# Altium schematic sheet DigitalMaze.SchDoc(DigitalMaze)
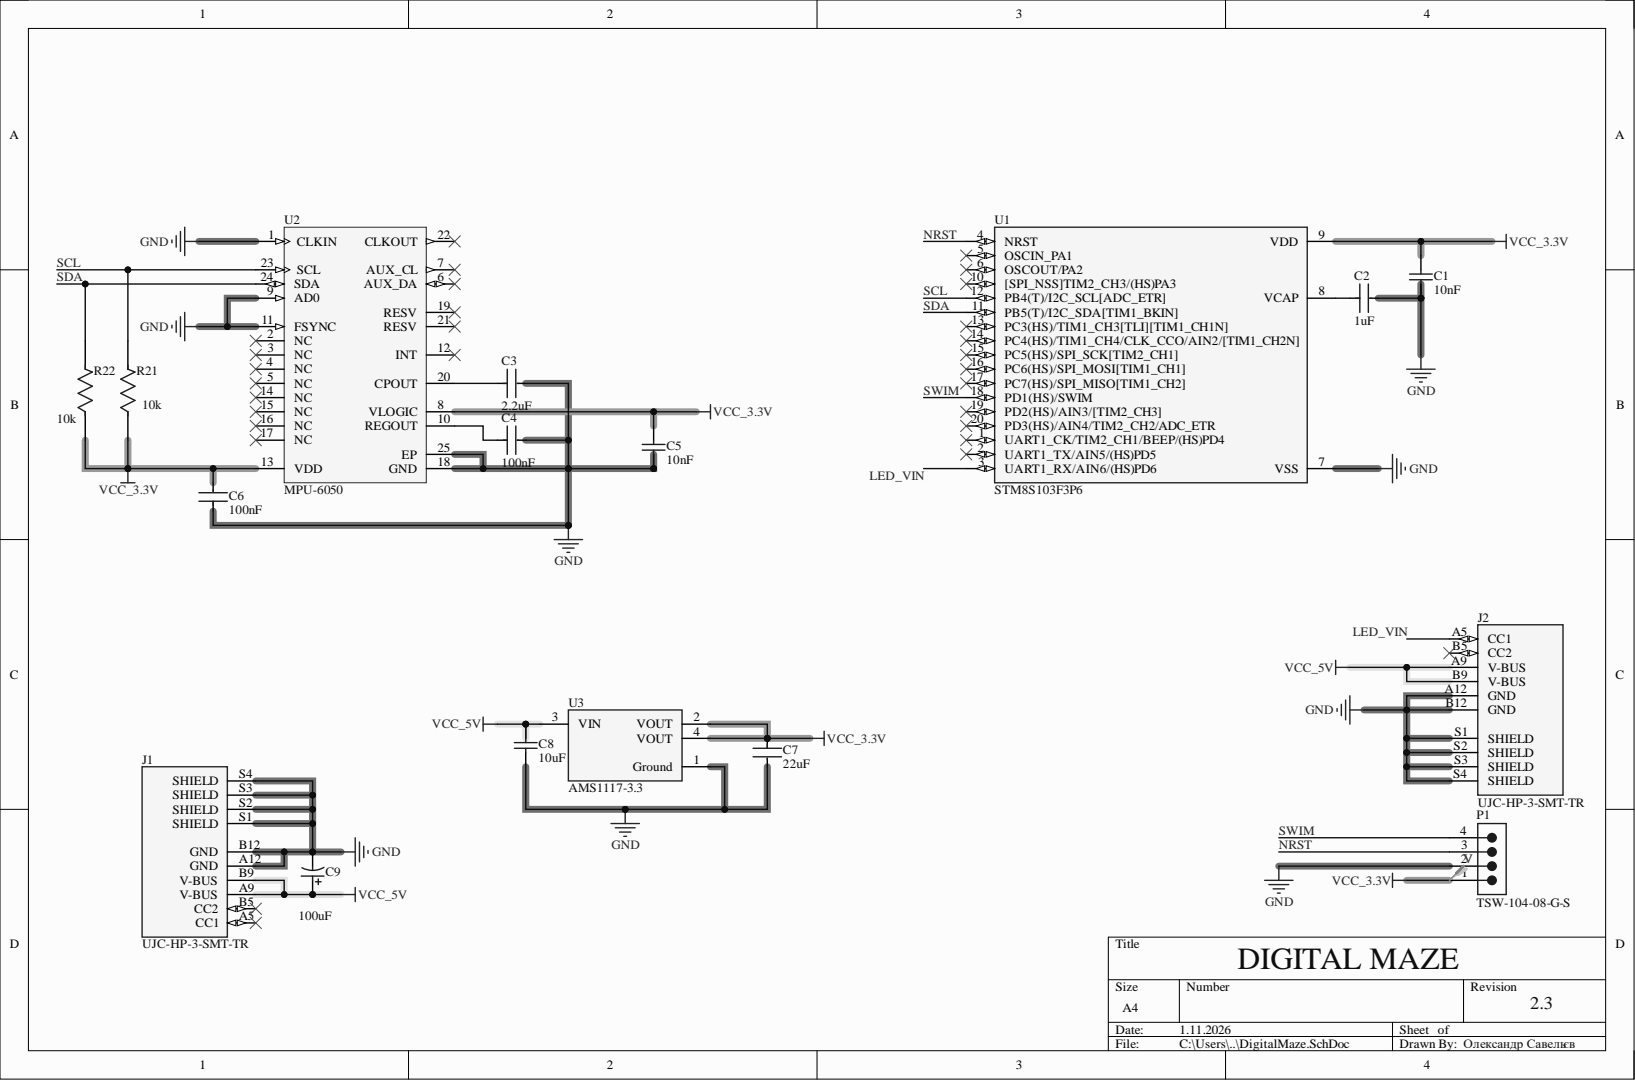
[diagram p0: DigitalMaze.SchDoc]

## Parts
C1  10nF  [CAPC1206(3216)150_N]
C2  1uF  [FP-CGA5L-0_3-0_1-MFG]
C3  2.2uF  [FP-1206-L_3_2_0_15-W_1_6-MFG]
C4  100nF  [FP-C1206C-EB-MFG]
C5  10nF  [CAPC1206(3216)150_N]
C6  100nF  [FP-C1206C-EB-MFG]
C7  22uF  [FP-GRM21B-0_2-e0_2_0_7-MFG]
C8  10uF  [CAPC1206(3216)190_N]
C9  100uF  [PNSC-FK-V-D8_V]
J1  UJC-HP-3-SMT-TR  [CUI_UJC-HP-3-SMT-TR]
J2  UJC-HP-3-SMT-TR  [CUI_UJC-HP-3-SMT-TR]
P1  TSW-104-08-G-S  [SMTC-TSW-104-08-X-S]
R21  10k  [FP-RT1206-MFG]
R22  10k  [FP-RT1206-MFG]
U1  STM8S103F3P6  [SOP65P640X120-20N]
U2  MPU-6050  [IS-QFN-24_V]
U3  AMS1117-3.3  [FP-AMS1117-IPC_C]

## Nets
GND: C1.1 C2.2 C3.2 C4.2 C5.1 C6.1 C7.2 C8.1 C9.2 J1.A12(GND) J1.B12(GND) J1.S1(SHIELD) J1.S2(SHIELD) J1.S3(SHIELD) J1.S4(SHIELD) J2.A12(GND) J2.B12(GND) J2.S1(SHIELD) J2.S2(SHIELD) J2.S3(SHIELD) J2.S4(SHIELD) P1.2 U1.7(VSS) U2.1(CLKIN) U2.9(AD0) U2.11(FSYNC) U2.18(GND) U2.25(EP) U3.1(Ground)
VCC_3.3V: C1.2 C5.2 C6.2 C7.1 P1.1 R21.1 R22.1 U1.9(VDD) U2.8(VLOGIC) U2.13(VDD) U3.2(VOUT) U3.4(VOUT)
VCC_5V: C8.2 C9.1 J1.A9(V-BUS) J1.B9(V-BUS) J2.A9(V-BUS) J2.B9(V-BUS) U3.3(VIN)
LED_VIN: J2.A5(CC1) U1.3(UART1_RX/AIN6/(HS)PD6)
NRST: P1.3 U1.4(NRST)
SCL: R21.2 U1.12(PB4(T)/I2C_SCL[ADC_ETR]) U2.23(SCL)
SDA: R22.2 U1.11(PB5(T)/I2C_SDA[TIM1_BKIN]) U2.24(SDA)
SWIM: P1.4 U1.18(PD1(HS)/SWIM)
NetC2_1: C2.1 U1.8(VCAP)
NetC3_1: C3.1 U2.20(CPOUT)
NetC4_1: C4.1 U2.10(REGOUT)

Not connected: J1 A5(CC1) B5(CC2); J2 B5(CC2); U1 1(UART1_CK/TIM2_CH1/BEEP/(HS)PD4) 2(UART1_TX/AIN5/(HS)PD5) 5(OSCIN_PA1) 6(OSCOUT/PA2) 10([SPI_NSS]TIM2_CH3/(HS)PA3) 13(PC3(HS)/TIM1_CH3[TLI][TIM1_CH1N]) 14(PC4(HS)/TIM1_CH4/CLK_CCO/AIN2/[TIM1_CH2N]) 15(PC5(HS)/SPI_SCK[TIM2_CH1]) 16(PC6(HS)/SPI_MOSI[TIM1_CH1]) 17(PC7(HS)/SPI_MISO[TIM1_CH2]) 19(PD2(HS)/AIN3/[TIM2_CH3]) 20(PD3(HS)/AIN4/TIM2_CH2/ADC_ETR); U2 2(NC) 3(NC) 4(NC) 5(NC) 6(AUX_DA) 7(AUX_CL) 12(INT) 14(NC) 15(NC) 16(NC) 17(NC) 19(RESV) 21(RESV) 22(CLKOUT)

## Library data of the parts
C1 C5 = CMP-1037-03492-1: CAP 10nF 25V ±10% 1206 (3216 Metric) Thickness 1.45mm SMD; Case-EIA: 1206; Case-Metric: 3216; Tolerance: Â±10%; Component Kind: Standard; Library Name: Altium Content Vault; Max Thickness: 1.45 mm; Rated Voltage: 25 V; Technology: SMT; Value: 10nF
C2 = CMP-08246-001699-1: Cap Ceramic 1uF 25V X7R 10% Pad SMD 1206 +125°C Automotive T/R; Capacitance: 1uF; Case Code (Imperial): 1206; Case Code (Metric): 3216; Case/Package: 1206; Component Kind: Standard; Composition: Ceramic; Depth: 1.6mm; Dielectric: X7R; Height: 1.6mm; Length: 3.2mm; Library Name: Altium Content Vault; Max Operating Temperature: 125°C; Min Operating Temperature: -55°C; Mount: Surface Mount; Package Quantity: 2000; Packaging: Tape and Reel; Ratings: AEC-Q200; REACH SVHC: No SVHC; Termination: SMD/SMT; Thickness: 0.075inch; Tolerance: 10%; Voltage Rating: 25V; Voltage Rating (DC): 25V; Weight: 0.000571oz; Width: 1.6mm
C3 = CMP-14477-002875-2: None; Dielectric: X7R; Tolerance: 20%; Voltage: 50V; Capacitance: 2.2uF; Component Kind: Standard; Depth: 1.6mm; Halogen Free: Halogen Free; Height: 1.6mm; Lead Free: Lead Free; Length: 3.2mm; Library Name: Altium Content Vault; Max Operating Temperature: 125°C; Min Operating Temperature: -55°C; Mount: Surface Mount; RoHS Compliant: Yes; Voltage Rating (DC): 50V
C4 C6 = CMP-03020-018297-1: C1206 0.10 μF U2J 20.00% 50 V; Manufacturer: KEMET; Manufacturer Part Number: C1206C104M5JACAUTO; Automotive Grade: Grade 0; Capacitance: 0.10 μF; Material: Ceramic; Temperature Coefficient: 15%; Tolerance: 0.2; Value: 0.10 μF; Voltage Rating: 50 V; Automotive: Yes; Category: Cap; Component Kind: Standard; ComponentLink2Description: Datasheet; ComponentLink2URL: https://content.kemet.com/datasheets/KEM_C1007_X8R_ULTRA_150C_SMD.pdf; Device Class L1: Passive Components; Device Class L2: Capacitors; Device Class L3: Ceramic Capacitors; Height: 0.88mm; Ipc Land Pattern Name: CAPC320160X78; Lead Free: Yes; Library Name: Altium Content Vault; Octopart: https://octopart.com/c1206c104m5jacauto-kemet-84732526; Package: C1206C-EB; RoHS: TRUE; Temperature: -55°C to +150°C; Temperature Characteristic: U2J; Temperature Range High: +150°C; Temperature Range Low: -55°C
C7 = CMP-06035-036790-1: Chip Multilayer Ceramic Capacitors for General Purpose, 0805, 22uF, X5R, 15%, 20%, 25V; Capacitance: 22uF; Case Code (Imperial): 0805; Case Code (Metric): 2012; Case/Package: 0805; Component Kind: Standard; Dielectric: X5R; Height: 1.25mm; Length: 0.079inch; Library Name: Altium Content Vault; Max Operating Temperature: 85°C; Min Operating Temperature: -55°C; Mount: Surface Mount; Package Quantity: 10000; Packaging: Tape and Reel; Resistor Type: Ceramic; Termination: SMD/SMT; Thickness: 0.057inch; Tolerance: 20%; Voltage Rating: 25V; Voltage Rating (DC): 25V; Weight: 0.000335oz; Width: 1.25mm
C8 = CMP-1037-04980-1: CAP 10uF 25V ±20% 1206 (3216 Metric) Thickness 1.9mm SMD; Case-EIA: 1206; Case-Metric: 3216; Tolerance: Â±20%; Component Kind: Standard; Library Name: Altium Content Vault; Max Thickness: 1.9 mm; Rated Voltage: 25 V; Technology: SMT; Value: 10uF
C9 = CMP-2000-06206-1: Aluminum Electrolytic Capacitor, 100 uF, +/- 20%, 25 V, 2-Pin SMD (Size Code D8), -55 to 105 degC, RoHS, Tape and Reel; Manufacturer: Panasonic; Capacitance: 100µF; Impedance: 0.34O; Lifetime@Temperature: 2000Hrs@105°C; Rated Voltage: 25V; Ripple Current: 280mA; Type: Can; Case Size/Dimension: Ø6.3x7.7mm; Component Kind: Standard; Datasheet URL: http://industrial.panasonic.com/cdbs/www-data/pdf/RDE0000/ABA0000C1181.pdf; Datasheet Version: 03/2016; Library Name: Altium Content Vault; Manufacturer URL: http://www.panasonic.com/; Mounting Technology: SurfaceMount; Operating Temp Range: -55to+105°C; Operating Temp-Max: 105°C; Package Description: 2-Pin Electrolytic Aluminum Capacitor, Body 6.6 x 6.6 mm; Package Reference: FK-V-D8; Package URL: http://industrial.panasonic.com/cdbs/www-data/pdf/RDE0000/DME0000COL48.pdf; Series: FK
P1 = CMP-1024-00357-1: 0.025" SQ Post Header, Through-hole, Vertical, -55 to 125 degC, 2.54 mm Pitch, 4-Pin, Male, RoHS; Manufacturer: Samtec; Pitch: 2.54 mm; Component Kind: Standard; ComponentLink1Description: Manufacturer URL; ComponentLink1URL: http://www.samtec.com; ComponentLink2Description: Datasheet; ComponentLink2URL: http://www.samtec.com/documents/webfiles/pdf/TSW_TH.PDF; ComponentLink3Description: Package Specification; ComponentLink3URL: http://www.samtec.com/documents/webfiles/cpdf/TSW-XXX-XX-XXX-X-XX-XXX-MKT.pdf; DatasheetVersion: F-214; Library Name: Altium Content Vault; Mounting Technology: Through-hole; Orientation: Vertical; PackageDescription: .025[0.64] SQ. Post Terminal Strip Assembly; PackageReference: TSW-104-08-X-S; PackageVersion: DM, 8/1988; PartNumber: TSW-104-08-G-S; RoHS: True; Temperature Range: -55 to 125 degC
R21 R22 = CMP-03412-025078-1: RES SMD 10K OHM 0.1% 1/4W 1206; Manufacturer: Yageo; Manufacturer Part Number: RT1206BRC0710KL; Power Rating: 0.25W; Resistance: 10KΩ; Temperature Coefficient: 15 ppm/°C; Tolerance: 0.005; Value: 10KΩ; Voltage Rating: 200V; Automotive: No; Category: Res; Component Kind: Standard; ComponentLink2Description: Datasheet; ComponentLink2URL: http://www.yageo.com/documents/recent/PYu-RT_1-to-0.01_RoHS_L_9.pdf; Composition: Thin Film; Device Class L1: Passive Components; Device Class L2: Resistors; Device Class L3: Chip SMD Resistors; Digikey Description: RES SMD 10K OHM 0.1% 1/4W 1206; Footprint Url: http://www.yageo.com/exep/pages/download/literatures/PYu-R_Mount_10.pdf; Height: 0.65mm; Ipc Land Pattern Name: RESC310160X55; Lead Free: Yes; Library Name: Altium Content Vault; Octopart: https://octopart.com/rt1206brc0710kl-yageo-30512400; Package: RT1206; RoHS: TRUE; Series: RT; Temperature: -55°C to +155°C; Temperature Range High: +155°C; Temperature Range Low: -55°C
U1 = STM8S103F3P6: STM8 series Microcontroller IC 8-Bit 16MHz 8KB (8K x 8) FLASH 20-TSSOP; MF: STMicroelectronics; Check_prices: https://www.snapeda.com/parts/STM8S103F3P6/STMicroelectronics/view-part/?ref=eda; Component Kind: Standard; Library Name: STM8S103F3P6.IntLib; MP: STM8S103F3P6; Package: TSSOP-20 STMicroelectronics; SnapEDA_Link: https://www.snapeda.com/parts/STM8S103F3P6/STMicroelectronics/view-part/?ref=snap
U2 = CMP-1753-00002-2: 3-Axis Gyroscope, Accelerometer and a Digital Motion Processor(TM) (DMP), 2.375 to 3.46 V, -40 to 85 degC, 24-Pin QFN, RoHS, Tape and Reel; Manufacturer: InvenSense; Dynamic Catalog: MPU-6000™Series; Featured Product: MPU-60506-Axis,IntegratedI²CSolution; Other Names: 1428-1007-2  MPU6050; Sensor Type: MotionTracking; Category: Sensors,Transducers; Component Kind: Standard; Datasheet URL: http://datasheet.octopart.com/MPU-6050-InvenSense-datasheet-14421344.pdf; Datasheet Version: Rev. 3.3, 05/2012; Family: Multifunction; Library Name: Altium Content Vault; Manufacturer URL: http://www.invensense.com/; Online Catalog: MPU-6000™Series; Output Type: Digital; Standard Package: 5,000; Unit PriceUSD: Digital
U3 = CMP-209535-000001-1: LDO Voltage Regulators 1A, 3.3V; Manufacturer: Advanced Monolithic Systems; Dropout Voltage: 1.1V; Max Junction Temp: +125°C; Max Power Dissipation: 1.2W; Max Supply Voltage: 15V; Nominal Supply Current: 5mA; Number Of Outputs: 1; Output Type: Fixed; Standoff Height: 0.64mm; Automotive: No; Category: IC; Component Kind: Standard; ComponentLink2Description: Datasheet; ComponentLink2URL: http://www.advanced-monolithic.com/pdf/ds1117.pdf; Device Class L1: Integrated Circuits (ICs); Device Class L2: Power Management ICs; Device Class L3: Voltage Regulators - Linear; Height: 1.8mm; Ipc Land Pattern Name: SOT229P700X180-4; Lead Free: Yes; Library Name: Altium Content Vault; Manufacturer Part Number: AMS1117-3.3; Octopart: https://octopart.com/ams1117-3.3-advanced+monolithic+systems-25899300; Output Current: 1A; Output Voltage: 3.3V; Package: AMS1117; RoHS: TRUE; Temperature: -40°C to +125°C; Temperature Range High: +125°C; Temperature Range Low: -40°C
J1 J2 = UJC-HP-3-SMT-TR: Availability: Unavailable; Component Kind: Standard; Library Name: UJC-HP-3-SMT-TR.IntLib; MP: UJC-HP-3-SMT-TR; Package: None; Price: None
